# Altium schematic sheet Measurements.SchDoc
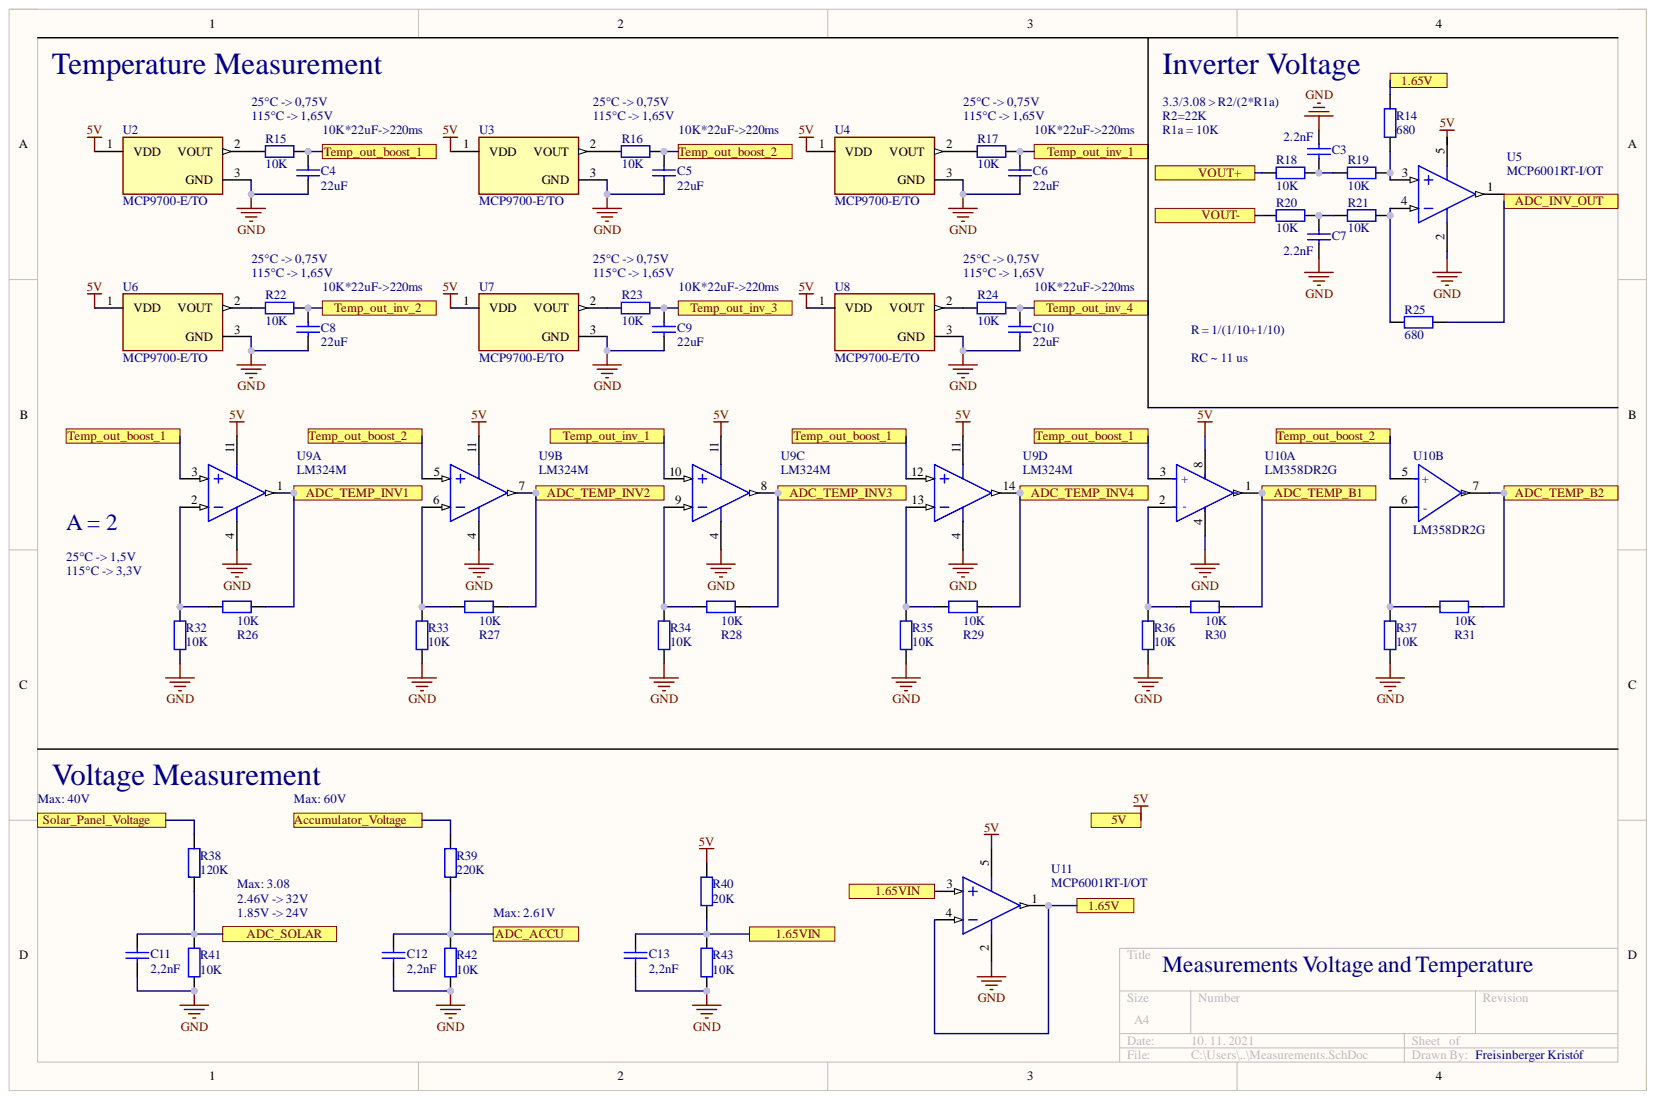
[diagram p0: Measurements.SchDoc]

## Parts
C3  2.2nF  [FP_0805_CAP_085]
C4  22uF  [FP_0805_CAP_085]
C5  22uF  [FP_0805_CAP_085]
C6  22uF  [FP_0805_CAP_085]
C7  2.2nF  [FP_0805_CAP_085]
C8  22uF  [FP_0805_CAP_085]
C9  22uF  [FP_0805_CAP_085]
C10  22uF  [FP_0805_CAP_085]
C11  2,2nF  [FP_0805_CAP_085]
C12  2,2nF  [FP_0805_CAP_085]
C13  2,2nF  [FP_0805_CAP_085]
R14  680  [FP_0603_RES]
R15  10K  [FP_0603_RES]
R16  10K  [FP_0603_RES]
R17  10K  [FP_0603_RES]
R18  10K  [FP_0603_RES]
R19  10K  [FP_0603_RES]
R20  10K  [FP_0603_RES]
R21  10K  [FP_0603_RES]
R22  10K  [FP_0603_RES]
R23  10K  [FP_0603_RES]
R24  10K  [FP_0603_RES]
R25  680  [FP_0603_RES]
R26  10K  [FP_0603_RES]
R27  10K  [FP_0603_RES]
R28  10K  [FP_0603_RES]
R29  10K  [FP_0603_RES]
R30  10K  [FP_0603_RES]
R31  10K  [FP_0603_RES]
R32  10K  [FP_0603_RES]
R33  10K  [FP_0603_RES]
R34  10K  [FP_0603_RES]
R35  10K  [FP_0603_RES]
R36  10K  [FP_0603_RES]
R37  10K  [FP_0603_RES]
R38  120K  [FP_0603_RES]
R39  220K  [FP_0603_RES]
R40  20K  [FP_0603_RES]
R41  10K  [FP_0603_RES]
R42  10K  [FP_0603_RES]
R43  10K  [FP_0603_RES]
U2  MCP9700-E/TO  [FP-C04-101-MFG]
U3  MCP9700-E/TO  [FP-C04-101-MFG]
U4  MCP9700-E/TO  [FP-C04-101-MFG]
U5  MCP6001RT-I/OT  [SOT-23-OT5_M]
U6  MCP9700-E/TO  [FP-C04-101-MFG]
U7  MCP9700-E/TO  [FP-C04-101-MFG]
U8  MCP9700-E/TO  [FP-C04-101-MFG]
U9  LM324M  [FP_SOIC14]  (parts A,B,C,D)
U10  LM358DR2G  [FP-751-07-IPC_C]  (parts A,B)
U11  MCP6001RT-I/OT  [SOT-23-OT5_M]

## Ports
1.65V, 1.65VIN, 5V, Accumulator_Voltage, ADC_ACCU, ADC_INV_OUT, ADC_SOLAR, ADC_TEMP_B1, ADC_TEMP_B2, ADC_TEMP_INV1, ADC_TEMP_INV2, ADC_TEMP_INV3, ADC_TEMP_INV4, Solar_Panel_Voltage, Temp_out_boost_1, Temp_out_boost_2, Temp_out_inv_1, Temp_out_inv_2, Temp_out_inv_3, Temp_out_inv_4, VOUT+, VOUT-

## Nets
GND: C3.2 C4.1 C5.1 C6.1 C7.1 C8.1 C9.1 C10.1 C11.1 C12.1 C13.1 R32.1 R33.1 R34.1 R35.1 R36.1 R37.1 R41.1 R42.1 R43.1 U2.3 U3.3 U4.3 U5.2 U6.3 U7.3 U8.3 U9.4 U10.4 U11.2
1.65V: R14.2 U11.1 U11.4 ; port 1.65V
1.65VIN: C13.2 R40.1 R43.2 U11.3 ; port 1.65VIN
5V: R40.2 U2.1 U3.1 U4.1 U6.1 U7.1 U8.1 U9.11 U10.8 U11.5 ; port 5V
Accumulator_Voltage: R39.2 ; port Accumulator_Voltage
ADC_ACCU: C12.2 R39.1 R42.2 ; port ADC_ACCU
ADC_INV_OUT: R25.2 ; port ADC_INV_OUT
ADC_SOLAR: C11.2 R38.1 R41.2 ; port ADC_SOLAR
ADC_TEMP_B1: R30.1 U10.1 ; port ADC_TEMP_B1
ADC_TEMP_B2: R31.1 U10.7 ; port ADC_TEMP_B2
ADC_TEMP_INV1: R26.1 U9.1 ; port ADC_TEMP_INV1
ADC_TEMP_INV2: R27.1 U9.7 ; port ADC_TEMP_INV2
ADC_TEMP_INV3: R28.1 U9.8 ; port ADC_TEMP_INV3
ADC_TEMP_INV4: R29.1 U9.14 ; port ADC_TEMP_INV4
Solar_Panel_Voltage: R38.2 ; port Solar_Panel_Voltage
Temp_out_boost_1: C4.2 R15.2 U9.3 U9.12 U10.3(+) ; port Temp_out_boost_1
Temp_out_boost_2: C5.2 R16.2 U9.5 U10.5(+) ; port Temp_out_boost_2
Temp_out_inv_1: C6.2 R17.2 U9.10 ; port Temp_out_inv_1
Temp_out_inv_2: C8.2 R22.2 ; port Temp_out_inv_2
Temp_out_inv_3: C9.2 R23.2 ; port Temp_out_inv_3
Temp_out_inv_4: C10.2 R24.2 ; port Temp_out_inv_4
NetC3_1: C3.1 R18.2 R19.1
NetC7_2: C7.2 R20.2 R21.1
NetR14_1: R14.1 R19.2 U5.3
NetR15_1: R15.1 U2.2
NetR16_1: R16.1 U3.2
NetR17_1: R17.1 U4.2
NetR18_1: R18.1
NetR20_1: R20.1
NetR21_2: R21.2 R25.1 U5.4
NetR22_1: R22.1 U6.2
NetR23_1: R23.1 U7.2
NetR24_1: R24.1 U8.2
NetR26_2: R26.2 R32.2 U9.2
NetR27_2: R27.2 R33.2 U9.6
NetR28_2: R28.2 R34.2 U9.9
NetR29_2: R29.2 R35.2 U9.13
NetR30_2: R30.2 R36.2 U10.2(-)
NetR31_2: R31.2 R37.2 U10.6(-)

Not connected: U5 1 5

## Library data of the parts
C3-C13 = CAP: Capacitor; Component Kind: Standard
  C3 C7: Value: 2.2nF
  C4-C6 C8-C10: Value: 22uF
  C11 C12: Library Name: DCDC_Converter_4_Channel.SchLib; Value: 2,2nF
  C13: Value: 2,2nF
  C3-C10 C13: Library Name: Napelem_Inverter.SchLib
R14-R43 = RES: Resistor; Component Kind: Standard
  R14 R25: Value: 680
  R15-R24 R26-R37 R42: Value: 10K
  R38: Library Name: DCDC_Converter_4_Channel.SchLib; Value: 120K
  R39: Value: 220K
  R40: Value: 20K
  R41 R43: Library Name: DCDC_Converter_4_Channel.SchLib; Value: 10K
  R14-R37 R39 R40 R42: Library Name: Napelem_Inverter.SchLib
U2-U4 U6-U8 = CMP-0192-00001-2: SENSOR ANALOG -40C-125C TO92-3; Manufacturer: Microchip; Import Ts: 1521170835; Max Junction Temp: +150°C; Max Supply Voltage: 5.5V; Min Supply Voltage: 2.3V; Operating Supply Current: 6uA; Output Current: 100uA; Output Voltage: 500mV; Sensor Output: Analog Voltage; Ambient Temperature Range High: +125°C; Ambient Temperature Range Low: -40°C; Automotive: No; Category: IC; Component Kind: Standard; ComponentLink2Description: Datasheet; ComponentLink2URL: http://ww1.microchip.com/downloads/en/DeviceDoc/20001942G.pdf; Device Class L1: Sensors; Device Class L2: Temperature and Humidity Sensors; Device Class L3: unset; Digikey Description: SENSOR ANALOG -40C-125C TO92-3; Footprint Url: http://ww1.microchip.com/downloads/en/DeviceDoc/3L_TO-92%20Plastic%20Transistor%20Outline_TO_C04-00101c.pdf; Height: 5.334mm; Ipc Land Pattern Name: TO-92; Lead Free: Yes; Library Name: Altium Content Vault; Manufacturer Part Number: MCP9700-E/TO; Mouser Description: Board Mount Temperature Sensors Lin Active Therm; Octopart: https://octopart.com/mcp9700-e%2Fto-microchip-668812; Package: C04-101; RoHS: TRUE; Temperature: -40°C to +125°C; Temperature Range High: +125°C; Temperature Range Low: -40°C
U5 U11 = CMP-0187-00469-2: 1 MHz, Low-Power Operational Amplifier, 5-Pin SOT-23, Industrial Temperature, Tape and Reel; Code_IPC: SOT23-5; Component Kind: Standard; ComponentLink1Description: Manufacturer URL; ComponentLink1URL: http://www.microchip.com/; ComponentLink2Description: Datasheet; ComponentLink2URL: http://ww1.microchip.com/downloads/en/DeviceDoc/21733j.pdf; ComponentLink3Description: Package Specification; ComponentLink3URL: http://www.microchip.com/stellent/groups/techpub_sg/documents/packagingspec/en012702.pdf; DatasheetVersion: revJ, Nov-2009; Library Name: Altium Content Vault; PackageDescription: 5-Lead Plastic Small Outline Transistor (OT) [SOT-23]; PackageReference: SOT-23-OT5; PackageVersion: revBB, Aug-2009; RevisionNote: URLs added.
U9 = LM324M: Low Power Quad Operational Amplifier, 14-pin Narrow SOIC; Code_IPC: SOIC127P600-14; Code_JEDEC: MS-012AB; Component Kind: Standard; ComponentLink1Description: LM324 Datasheet; ComponentLink1URL: http://www.national.com/ds/LM/LM324.pdf; ComponentLink2Description: LM324 Web Page; ComponentLink2URL: http://www.national.com/pf/LM/LM324.html; ComponentLink3Description: M14A Package Page; ComponentLink3URL: http://www.national.com/packaging/folders/m14a.html; Library Name: Napelem_Inverter.SchLib
U10 = CMP-1310-00291-2: IC OPAMP GP 2 CIRCUIT 8SOIC; Bandwidth: 1MHz; Common Mode Rejection Ratio: 65dB; Dual Supply Voltage: 9V; Input Bias Current: 250nA; Input Offset Voltage (Vos): 7mV; Max Dual Supply Voltage: 16V; Max Supply Voltage: 32V; Min Dual Supply Voltage: 1.5V; Min Supply Voltage: 3V; Nominal Supply Current: 1.2mA; Number of Amplifiers: 2; Operating Supply Current: 1.5mA; Output Current: 40mA; Slew Rate: 0.6V/µs; Voltage Gain: 100dB; Case/Package: SOIC; Component Kind: Standard; Contact Plating: Tin; Gain Bandwidth Product: 1MHz; Halogen Free: Halogen Free; Height: 1.5mm; Lead Free: Lead Free; Length: 5mm; Library Name: Altium Content Vault; Max Operating Temperature: 70°C; Min Operating Temperature: 0°C; Number of Channels: 2; Number of Circuits: 2; Number of Elements: 2; Number of Pins: 8; Operating Supply Voltage: 16V; Output Current per Channel: 40mA; Package Quantity: 2500; Packaging: Tape and Reel; Power Supply Rejection Ratio (PSRR): 65dB; Radiation Hardening: No; REACH SVHC: No SVHC; Width: 4mm
